# Altium schematic sheet StudentForce_PWE.SchDoc
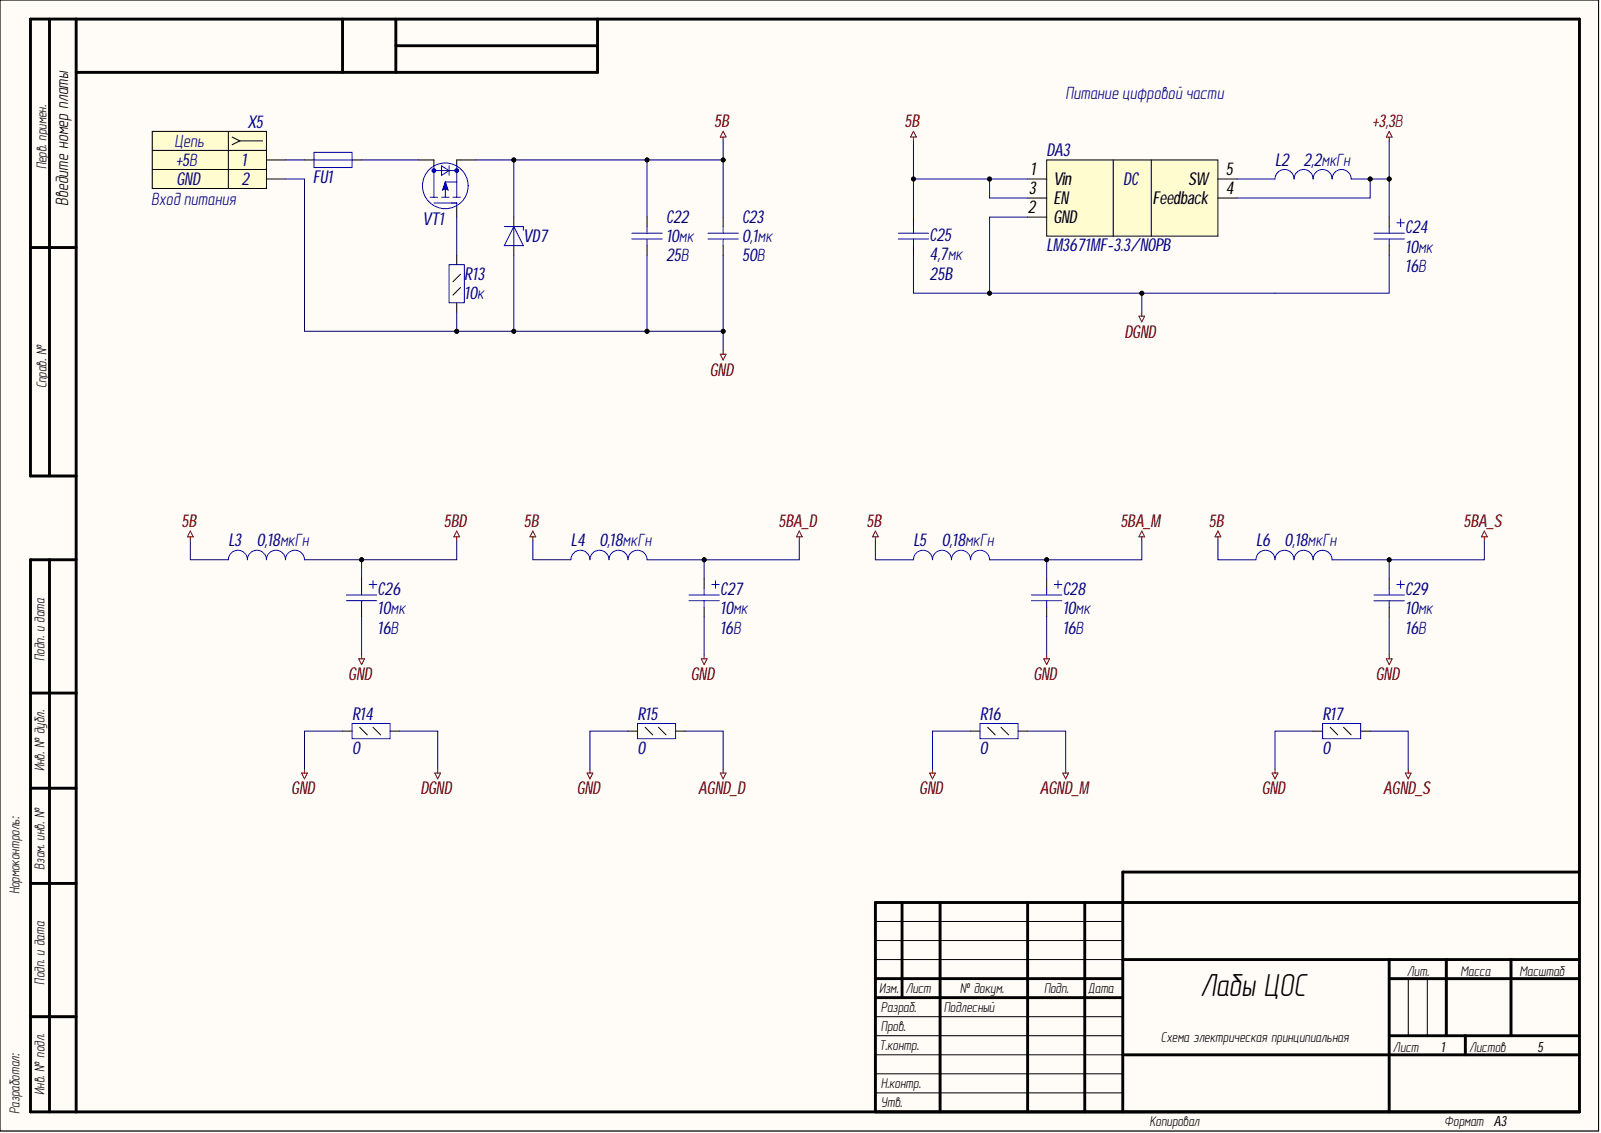
[diagram p0: StudentForce_PWE.SchDoc]

## Parts
C22  value 10мк  Voltage: 25В  [C1206]  (hidden comment: Capacitor)
C23  value 0,1мк  Voltage: 50В  [C0805]  (hidden comment: Capacitor)
C24  value 10мк  Voltage: 16В  [TANTAL CASE A]  (hidden comment: Capacitor_pol)
C25  value 4,7мк  Voltage: 25B  [C0805]  (hidden comment: Capacitor)
C26  value 10мк  Voltage: 16В  [TANTAL CASE A]  (hidden comment: Capacitor_pol)
C27  value 10мк  Voltage: 16В  [TANTAL CASE A]  (hidden comment: Capacitor_pol)
C28  value 10мк  Voltage: 16В  [TANTAL CASE A]  (hidden comment: Capacitor_pol)
C29  value 10мк  Voltage: 16В  [TANTAL CASE A]  (hidden comment: Capacitor_pol)
DA3  LM3671MF  Part Number: LM3671MF-3.3/NOPB  [SOT23-5]
FU1  Fuse  [FUSE812]  (value not drawn)
L2  value 2,2мкГн  [SDR0604]  (hidden comment: Inductor)
L3  value 0,18мкГн  [L0805]  (hidden comment: Inductor)
L4  value 0,18мкГн  [L0805]  (hidden comment: Inductor)
L5  value 0,18мкГн  [L0805]  (hidden comment: Inductor)
L6  value 0,18мкГн  [L0805]  (hidden comment: Inductor)
R13  value 10к  [R0805]  (hidden comment: Resistor_0,125Вт)
R14  value 0  [R0805]  (hidden comment: Resistor_0,125Вт)
R15  value 0  [R0805]  (hidden comment: Resistor_0,125Вт)
R16  value 0  [R0805]  (hidden comment: Resistor_0,125Вт)
R17  value 0  [R0805]  (hidden comment: Resistor_0,125Вт)
VD7  DiodeZener  [SMA]  (value not drawn)
VT1  P-MOSFET  [SOT23-3]  (value not drawn)
X5  Вход питания  Contact_1: +5В  Contact_2: GND  [DS-210]

## Nets
5B: C22.2 C23.2 C25.2 DA3.1(Vin) DA3.3(EN) L3.1 L4.1 L5.1 L6.1 VD7.1 VT1.2
5BA_D: C27.1 L4.2
5BA_M: C28.1 L5.2
5BA_S: C29.1 L6.2
5BD: C26.1 L3.2
+3,3В: C24.1 DA3.4(Feedback) L2.2
AGND_D: R15.2
AGND_M: R16.2
AGND_S: R17.2
DGND: C24.2 C25.1 DA3.2(GND) R14.2
GND: C22.1 C23.1 C26.2 C27.2 C28.2 C29.2 R13.1 R14.1 R15.1 R16.1 R17.1 VD7.2 X5.2
NetDA3_5: DA3.5(SW) L2.1
NetFU1_1: FU1.1 X5.1
NetFU1_2: FU1.2 VT1.3
NetR13_2: R13.2 VT1.1

## Library data of the parts
C22 = cap186: Конденсатор; Manufacture: Murata; Part Name: Ceramic; Part Number: GRM31CR71E106KA12L*; Database Table Name: Capacitors$; TKE: X7R; Component Kind: Standard; id: cap186; Library Name: MOP44.DbLib
C23 = cap160: Конденсатор; Manufacture: Murata; Part Name: Ceramic; Part Number: GRM21BR71H104KA01L; Database Table Name: Capacitors$; TKE: X7R; Component Kind: Standard; id: cap160; Library Name: MOP44.DbLib
C24 C26-C29 = cap199: Конденсатор полярный; Manufacture: Vishay; Part Name: Tantal; Part Number: 293D106X9016A2TE3; Database Table Name: Capacitors$; Component Kind: Standard; id: cap199; Library Name: MOP44.DbLib
C25 = cap153: Конденсатор; Manufacture: Murata; Part Name: Ceramic; Part Number: GRM21BR61E475KA12L; Database Table Name: Capacitors$; TKE: X5R; Component Kind: Standard; id: cap153; Library Name: MOP44.DbLib
DA3 = pwr2: Step-Down DC-DC Converter; Manufacturer: Texas Instruments; Part Name: 600-mA Step-Down DC-DC Converter; Current: 0,6A; Database Table Name: PowerTransducer$; Input Voltage: 2,7 - 5,5B; Output Voltage: 3,3B; Component Kind: Standard; id: pwr2; Library Name: MOP44.DbLib; Type: Impulse
FU1 = fuse0: Предохранитель; Manufacture: Bourns; Part Name: Resettable Fuses; Part Number: MF-MSMF075; Database Table Name: Fuse$; I hold: 0,75A; I max: 100A; I trip: 1,5A; Resistance: 0,45Ohms; V max: 13,2B; Component Kind: Standard; id: fuse0; Library Name: MOP44.DbLib
L2 = ind34: EMI Suppression Filter; Manufacture: Bourns; Part Name: SMD Power Inductors; Part Number: SDR0604-2R2ML; Database Table Name: Inductor$; Rated Current: 2,8A; Resistance: 0,06Ohm; Saturation Current: 5,1A; Component Kind: Standard; id: ind34; Library Name: MOP44.DbLib
L3-L6 = ind2: EMI Suppression Filter; Part Number: CM201212-R18KL; Database Table Name: Inductor$; Rated Current: 120-540мА; Component Kind: Standard; id: ind2; Library Name: MOP44.DbLib; Part Name: Inductor
R13 = res97: Резистор; Manufacture: Yageo; Part Number: RC0805FR-0710KL; Accuracy: 0.01; Database Table Name: Resistor$; Component Kind: Standard; id: res97; Library Name: MOP44.DbLib; Part Name: Resistor; Power: 0,125Вт
R14-R17 = res0: Резистор; Manufacture: Yageo; Part Number: RC0805FR-070RL; Accuracy: 0.01; Database Table Name: Resistor$; Component Kind: Standard; id: res0; Library Name: MOP44.DbLib; Part Name: Resistor; Power: 0,125Вт
VD7 = SMAJ5.0A-TR: Cтабилитрон (лавинный выпрямительный диод) односторонний; Manufacture: ST Microelectronics; Part Name: TVS diode Unidirectional; Breakdown Voltage: 6,74B; Clamping voltage: 13,4B; Database Table Name: TVSdiode$; Dynamic resistance: 49Ohm; id: tvs155; Leakage Current: 0,2uA; Number of diodes: 1; Peak Pulse Power: 400W; Stand-off Voltage: 5B; Component Kind: Standard; Library Name: MOP44.DbLib; Part Number: SMAJ5.0A-TR
VT1 = mosfet3: N-MOSFET; Part Name: Transistor; Part Number: IRLML6401TRPBF; Continuous drain current: 4,3A; Database Table Name: MOSFETTransistor$; Gate-to-source voltage: (+-)8B; Power dissipation: 1,3W; Pulsed drain current: 34A; Rds: 0,05Ohm; Structure: p-channel; V drain-source max: (-12B); Component Kind: Standard; id: mosfet3; Library Name: MOP44.DbLib
X5 = connect1: Разъем - 2 конт. розетка; Part Name: DC Power Jack; Part Number: DS-210B(PBT)(NB); Database Table Name: Connector$; Component Kind: Standard; id: connect1; Library Name: MOP44.DbLib
